# Altium schematic sheet ESP8266.SchDoc
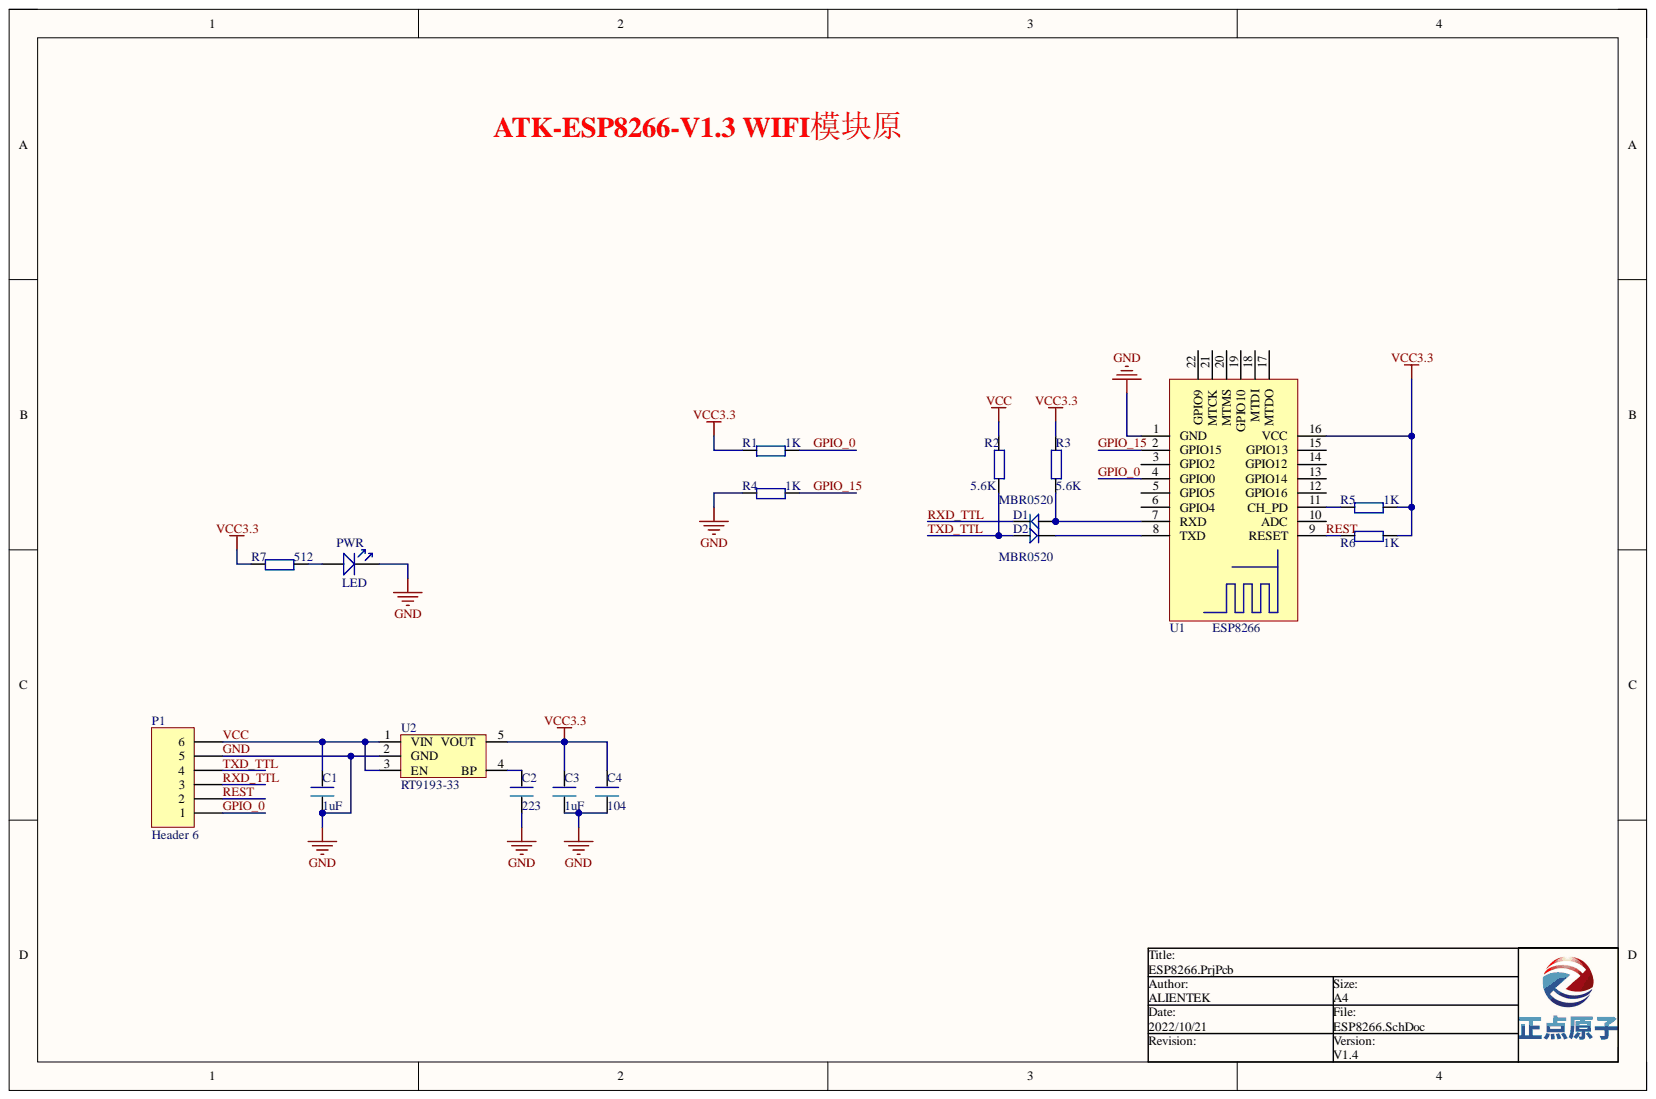
[diagram p0: ESP8266.SchDoc]

## Parts
C1  1uF  [0603P]
C2  223  [0603P]
C3  1uF  [0603P]
C4  104  [0603P]
D1  MBR0520  [SOD-123]
D2  MBR0520  [SOD-123]
P1  Header 6  [HDR1X6]
PWR  LED  [SMDLED]
R1  1K  [0603P]
R2  5.6K  [0603P]
R3  5.6K  [0603P]
R4  1K  [0603P]
R5  1K  [0603P]
R6  1K  [0603P]
R7  512  [0603P]
U1  ESP8266  [ESP8266]
U2  RT9193-33  [SOT-23-5]

## Nets
VCC3.3: C3.2 C4.2 R1.1 R3.2 R5.2 R6.2 R7.1 U1.16(VCC) U2.5(VOUT)
GND: C1.1 C2.1 C3.1 C4.1 P1.5 PWR.2 R4.1 U1.1(GND) U2.2(GND)
GPIO_0: P1.1 R1.2 U1.4(GPIO0)
GPIO_15: R4.2 U1.2(GPIO15)
REST: P1.2 R6.1 U1.9(RESET)
RXD_TTL: D1.2 P1.3
TXD_TTL: D2.1 P1.4 R2.1
VCC: C1.2 P1.6 R2.2 U2.1(VIN) U2.3(EN)
NetC2_2: C2.2 U2.4(BP)
NetD1_1: D1.1 R3.1 U1.7(RXD)
NetD2_2: D2.2 U1.8(TXD)
NetPWR_1: PWR.1 R7.2
NetR5_1: R5.1 U1.11(CH_PD)

Not connected: U1 3(GPIO2) 5(GPIO5) 6(GPIO4) 10(ADC) 12(GPIO16) 13(GPIO14) 14(GPIO12) 15(GPIO13) 17(MTDO) 18(MTDI) 19(GPIO10) 20(MTMS) 21(MTCK) 22(GPIO9)

## Library data of the parts
C1-C4 = C: Component Kind: Standard; Library Name: ATK-HC05蓝牙串口模块封装库.IntLib
D1 D2 = D: 1N4148; Component Kind: Standard; Library Name: ATK-HC05蓝牙串口模块封装库.IntLib
P1 = Header 6: Header, 6-Pin; Component Kind: Standard; LatestRevisionDate: 17-Jul-2002; LatestRevisionNote: Re-released for DXP Platform.; Library Name: Miscellaneous Connectors.IntLib; Signal Integrity: Connector; Publisher: Altium Limited
R1-R7 = R: Component Kind: Standard
  R2 R3: Library Name: ALIENTEK_COMPONENT.IntLib
  R1 R4-R7: Library Name: ATK-HC05蓝牙串口模块封装库.IntLib
U2 = RT9193-33: 3.3V超低压差LDO; Component Kind: Standard; Library Name: ATK-HC05蓝牙串口模块封装库.IntLib
PWR = LED: Component Kind: Standard; Library Name: ATK-HC05蓝牙串口模块封装库.IntLib
U1 = ESP8266: Component Kind: Standard; Library Name: ad_lib.SchLib
